# Altium schematic sheet esp32.SchDoc
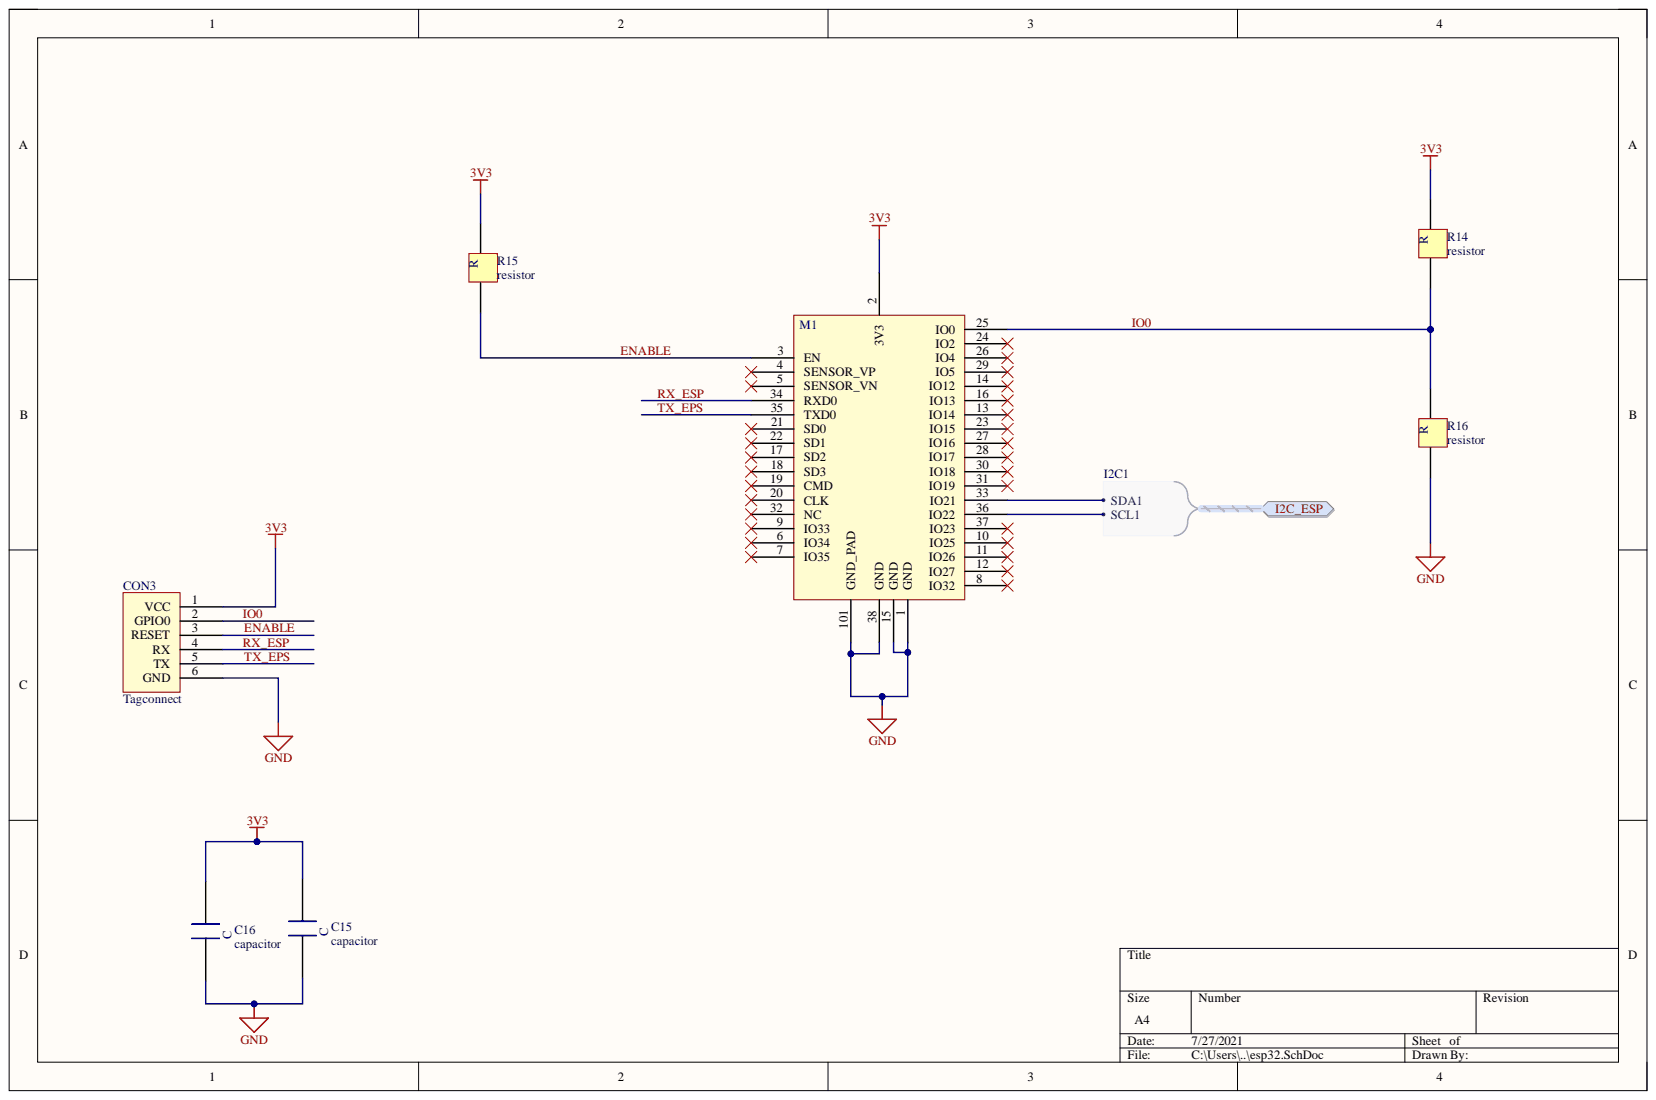
[diagram p0: esp32.SchDoc]

## Parts
C15  capacitor  [CAPC1005X04L]
C16  capacitor  [CAPC1005X04L]
CON3  Tagconnect  [Tagconnect]
M1  ESP32S_Module  [ESP32S]  (value not drawn)
R14  resistor  [CAPC1005X04L]
R15  resistor  [CAPC1005X04L]
R16  resistor  [CAPC1005X04L]

## Ports
I2C_ESP, I2C_ESP.SCL1, I2C_ESP.SDA1

## Nets
3V3: C15.1 C16.1 CON3.1(VCC) M1.2(3V3) R14.1 R15.1
GND: C15.2 C16.2 CON3.6(GND) M1.1(GND) M1.15(GND) M1.38(GND) M1.101(GND_PAD) R16.2
ENABLE: CON3.3(RESET) M1.3(EN) R15.2
IO0: CON3.2(GPIO0) M1.25(IO0) R14.2 R16.1
RX_ESP: CON3.4(RX) M1.34(RXD0)
TX_EPS: CON3.5(TX) M1.35(TXD0)
NetM1_4: M1.4(SENSOR_VP)
NetM1_5: M1.5(SENSOR_VN)
NetM1_6: M1.6(IO34)
NetM1_7: M1.7(IO35)
NetM1_8: M1.8(IO32)
NetM1_9: M1.9(IO33)
NetM1_10: M1.10(IO25)
NetM1_11: M1.11(IO26)
NetM1_12: M1.12(IO27)
NetM1_13: M1.13(IO14)
NetM1_14: M1.14(IO12)
NetM1_16: M1.16(IO13)
NetM1_17: M1.17(SD2)
NetM1_18: M1.18(SD3)
NetM1_19: M1.19(CMD)
NetM1_20: M1.20(CLK)
NetM1_21: M1.21(SD0)
NetM1_22: M1.22(SD1)
NetM1_23: M1.23(IO15)
NetM1_24: M1.24(IO2)
NetM1_26: M1.26(IO4)
NetM1_27: M1.27(IO16)
NetM1_28: M1.28(IO17)
NetM1_29: M1.29(IO5)
NetM1_30: M1.30(IO18)
NetM1_31: M1.31(IO19)
NetM1_32: M1.32(NC)
NetM1_33: M1.33(IO21)
NetM1_36: M1.36(IO22)
NetM1_37: M1.37(IO23)
N00001: ; port I2C_ESP
N00002: ; port I2C_ESP

## Library data of the parts
M1 = ESP32S_Module: Esp-32S; Component Kind: Standard; Datasheet: https://espressif.com/sites/default/files/documentation/esp_wroom_32_datasheet_en.pdf; Library Name: ESPS.SchLib
C15 C16 = capacitor: Component Kind: Standard; Library Name: pasives.SchLib
CON3 = Tagconnect: Component Kind: Standard; Library Name: conector's_and_TP.SchLib
R14-R16 = resistor: Component Kind: Standard; Library Name: pasives.SchLib
